# Altium schematic sheet STM32.SchDoc(STM32)
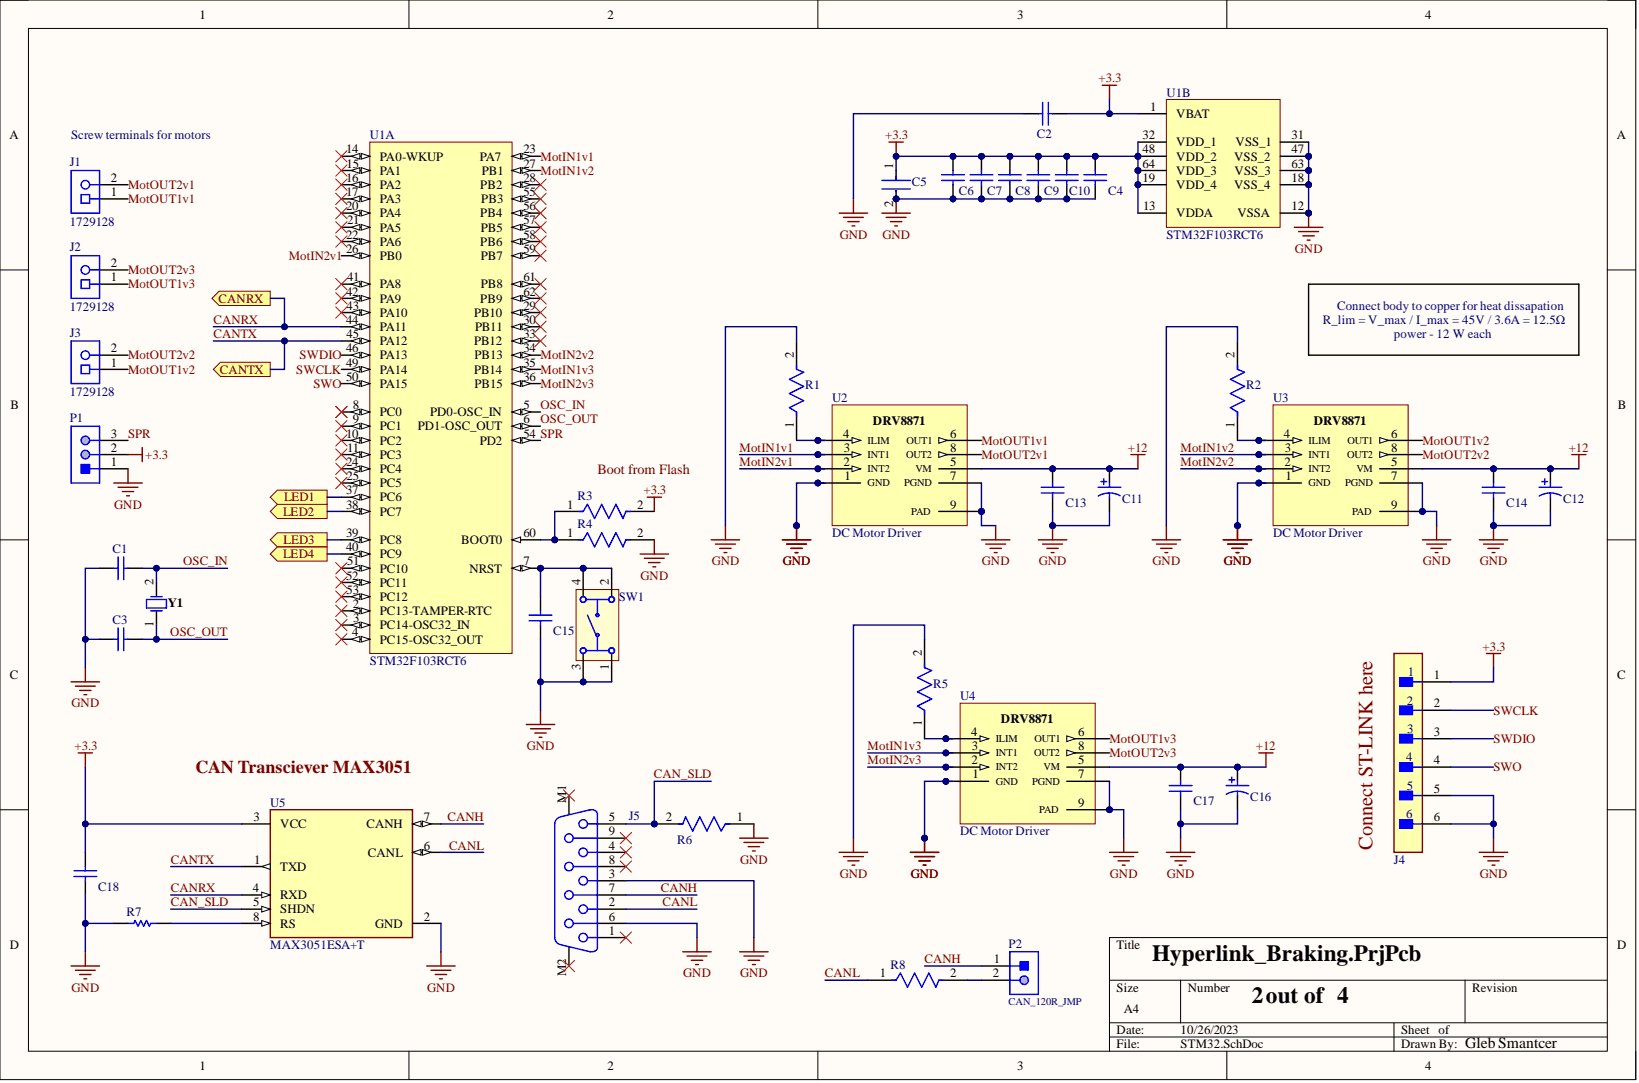
[diagram p0: STM32.SchDoc]

## Parts
C1  GRM1885C2A100JA01D  (value not drawn)
C2  GRM188R71H104KA93D  (value not drawn)
C3  GRM1885C2A100JA01D  (value not drawn)
C4  GRM188R71A105KA61D  (value not drawn)
C5  GRM188R61E475KE11D  (value not drawn)
C6  GRM188R71H104KA93D  (value not drawn)
C7  GRM188R71H104KA93D  (value not drawn)
C8  GRM188R71H104KA93D  (value not drawn)
C9  GRM188R71H104KA93D  (value not drawn)
C10  GRM188R71H104KA93D  (value not drawn)
C11  EEE-HA1H470XP  (value not drawn)
C12  EEE-HA1H470XP  (value not drawn)
C13  GRM188R71H104KA93D  (value not drawn)
C14  GRM188R71H104KA93D  (value not drawn)
C15  GRM188R71H104KA93D  (value not drawn)
C16  EEE-HA1H470XP  (value not drawn)
C17  GRM188R71H104KA93D  (value not drawn)
C18  GRM188R71H104KA93D  (value not drawn)
J1  1729128
J2  1729128
J3  1729128
J4  901210766  (value not drawn)
J5  618009231121  (value not drawn)
P1  S3B-XH-A(LF)(SN)  (value not drawn)
P2  CAN_120R_JMP
R1  CRCW060314R0FKEA  (value not drawn)
R2  CRCW060314R0FKEA  (value not drawn)
R3  0Ω  (value not drawn)  (no BOM)
R4  0Ω  (value not drawn)
R5  CRCW060314R0FKEA  (value not drawn)
R6  CRCW060310K0JNEA  (value not drawn)
R7  CRCW060310K0JNEA  (value not drawn)
R8  CRCW0603120RJNEA  (value not drawn)
SW1  430182070816  (value not drawn)
U1  STM32F103RCT6  (parts A,B)
U2  DC Motor Driver
U3  DC Motor Driver
U4  DC Motor Driver
U5  MAX3051ESA+T
Y1  FOXSDLF/080-20  (value not drawn)

## Ports
CANRX, CANTX, LED1, LED2, LED3, LED4

## Nets
+3.3: C2.2 C4.2 C5.1 C6.2 C7.2 C8.2 C9.2 C10.2 C18.2 J4.1 P1.2 R3.2 U1.1(VBAT) U1.13(VDDA) U1.19(VDD_4) U1.32(VDD_1) U1.48(VDD_2) U1.64(VDD_3) U5.3(VCC)
+12: C11.1 C12.1 C13.2 C14.2 C16.1 C17.2 U2.5(VM) U3.5(VM) U4.5(VM)
GND: C1.1 C2.1 C3.1 C4.1 C5.2 C6.1 C7.1 C8.1 C9.1 C10.1 C11.2 C12.2 C13.1 C14.1 C15.1 C16.2 C17.1 C18.1 J4.5 J4.6 J5.3 J5.6 P1.1 R1.2 R2.2 R4.2 R5.2 R6.1 R7.2 SW1.1 SW1.3 U1.12(VSSA) U1.18(VSS_4) U1.31(VSS_1) U1.47(VSS_2) U1.63(VSS_3) U2.1(GND) U2.7(PGND) U2.9(PAD) U3.1(GND) U3.7(PGND) U3.9(PAD) U4.1(GND) U4.7(PGND) U4.9(PAD) U5.2(GND)
CAN_SLD: J5.5 R6.2 U5.5(SHDN)
CANH: J5.7 P2.1 U5.7(CANH)
CANL: J5.2 R8.1 U5.6(CANL)
CANRX: U1.44(PA11) U5.4(RXD) ; port CANRX
CANTX: U1.45(PA12) U5.1(TXD) ; port CANTX
LED1: U1.37(PC6) ; port LED1
LED2: U1.38(PC7) ; port LED2
LED3: U1.39(PC8) ; port LED3
LED4: U1.40(PC9) ; port LED4
MotIN1v1: U1.23(PA7) U2.3(INT1)
MotIN1v2: U1.27(PB1) U3.3(INT1)
MotIN1v3: U1.35(PB14) U4.3(INT1)
MotIN2v1: U1.26(PB0) U2.2(INT2)
MotIN2v2: U1.34(PB13) U3.2(INT2)
MotIN2v3: U1.36(PB15) U4.2(INT2)
MotOUT1v1: J1.1 U2.6(OUT1)
MotOUT1v2: J3.1 U3.6(OUT1)
MotOUT1v3: J2.1 U4.6(OUT1)
MotOUT2v1: J1.2 U2.8(OUT2)
MotOUT2v2: J3.2 U3.8(OUT2)
MotOUT2v3: J2.2 U4.8(OUT2)
OSC_IN: C1.2 U1.5(PD0-OSC_IN) Y1.2
OSC_OUT: C3.2 U1.6(PD1-OSC_OUT) Y1.1
SPR: P1.3 U1.54(PD2)
SWCLK: J4.2 U1.49(PA14)
SWDIO: J4.3 U1.46(PA13)
SWO: J4.4 U1.50(PA15)
NetC15_2: C15.2 SW1.2 SW1.4 U1.7(NRST)
NetP2_2: P2.2 R8.2
NetR1_1: R1.1 U2.4(ILIM)
NetR2_1: R2.1 U3.4(ILIM)
NetR3_1: R3.1 R4.1 U1.60(BOOT0)
NetR5_1: R5.1 U4.4(ILIM)
NetR7_1: R7.1 U5.8(RS)

Not connected: J5 1 4 8 9 M1 M2; U1 2(PC13-TAMPER-RTC) 3(PC14-OSC32_IN) 4(PC15-OSC32_OUT) 8(PC0) 9(PC1) 10(PC2) 11(PC3) 14(PA0-WKUP) 15(PA1) 16(PA2) 17(PA3) 20(PA4) 21(PA5) 22(PA6) 24(PC4) 25(PC5) 28(PB2) 29(PB10) 30(PB11) 33(PB12) 41(PA8) 42(PA9) 43(PA10) 51(PC10) 52(PC11) 53(PC12) 55(PB3) 56(PB4) 57(PB5) 58(PB6) 59(PB7) 61(PB8) 62(PB9)

## Library data of the parts
C1 C3 = CMP-1035-00565-2: Manufacturer: Murata; Component Kind: Standard; JLC: C1634; Library Name: Altium Content Vault; Part Number: GRM1885C2A100JA01D
C2 C6-C10 C13-C15 C17 C18 = CMP-2100-03643-1: Chip Capacitor, 0.1 uF, +/- 10%, 50 V, -55 to 125 degC, 0603 (1608 Metric), RoHS, Tape and Reel; Manufacturer: Murata; Capacitance: 100nF; Dielectric: X7R; Dielectric Material: Ceramic; Insulation Resistance: 10GR; Voltage: 50V; Case Code (Imperial): 0603; Case Code (Metric): 1608; Case/Package: 0603; Component Kind: Standard; Contact Plating: Tin; Datasheet URL: http://psearch.en.murata.com/capacitor/product/GRM188R71H104KA93%23.pdf; Datasheet Version: 42675.0; Depth: 0.8mm; Height: 0.8mm; JLC: C14663; Lead Free: LeadFree; Length: 1.6mm; Library Name: Altium Content Vault; Manufacturer URL: http://www.murata.com/; Material: Ceramic; Max Operating Temperature: 125degC; Min Operating Temperature: -55degC; Mount: SurfaceMount; Number of Pins: 2; Package Description: 2-Pin Surface Mount Device, Body 1.6 x 0.8 mm; Package Quantity: 1; Package Reference: 0603; Packaging: TapeandReel; Radiation Hardening: No; REACH SVHC: NoSVHC; RoHSCompliant: true; Termination: SMD/SMT; Thickness: 0.035inch; Tolerance: 10%; Voltage Rating: 50V; Voltage Rating (DC): 50V; Width: 0.8mm
C4 = CMP-2006-02831-1: Manufacturer: Murata; Component Kind: Standard; JLC: C15849; Library Name: Altium Content Vault; Part Number: GRM188R71A105KA61D
C5 = CMP-2006-02523-2: Chip Multilayer Ceramic Capacitors for General Purpose, 0603, 4.7uF, X5R, 15%, 10%, 25V; Capacitance: 4.7uF; Case Code (Imperial): 0603; Case Code (Metric): 1608; Case/Package: 0603; Component Kind: Standard; Depth: 0.8mm; Dielectric: X5R; Height: 0.8mm; JLC: C19666; Lead Free: Lead Free; Length: 1.6mm; Library Name: Altium Content Vault; Material: Ceramic; Max Operating Temperature: 85°C; Min Operating Temperature: -55°C; Mount: Surface Mount; Package Quantity: 4000; Packaging: Cut Tape; REACH SVHC: No SVHC; Thickness: 0.031inch; Tolerance: 10%; Voltage: 25V; Voltage Rating: 25V; Voltage Rating (DC): 25V; Width: 0.031inch
C11 C12 C16 = CMP-0736-00001-1: Aluminum Electrolytic Capacitor, 47 uF +/- 20%, 50 V, -40 to 105 degC, 2-Pin 6.6 x 6.6 x 8 mm SMD, RoHS, Tape and Reel, Tape and Reel; Manufacturer: Panasonic; Cap.: 47 uF; Capacitance Tolerance: +/- 20%; Rated Voltage: 50 V; Ripple Current: 63 mA; Component Kind: Standard; ComponentLink1Description: Manufacturer URL; ComponentLink1URL: http://industrial.panasonic.com/; ComponentLink2Description: Datasheet; ComponentLink2URL: http://industrial.panasonic.com/www-data/pdf/ABA0000/ABA0000CE3.pdf; ComponentLink3Description: Package Specification; ComponentLink3URL: http://industrial.panasonic.com/www-data/pdf/ABA0000/ABA0000PE248.pdf; DatasheetVersion: Sep-13; JLC: C249976; Library Name: Altium Content Vault; Mounting Technology: Surface Mount; PackageDescription: 2-Pin SMD, Body 6.6 x 6.6 mm, Height 8 mm; PackageReference: EEE-HA1H470XP-2; PackageVersion: Sep-13; Packing: Tape and Reel; PartNumber: EEE-HA1H470XP; RoHS: TRUE; Temp. Range: -40 to 105 degC
J1-J3 = CMP-2000-05815-1: PCB Terminal Block, 13.5 A, 400 V, 2-Pin THD, RoHS, Bulk; Manufacturer: Phoenix Contact; Current Rating: 13500mA; No. of Positions: 2; No. of Rows: 1; Package Version: 03/2015; Pitch: 5.08mm; Voltage Rating: 400V; Component Kind: Standard; Datasheet URL: https://datasheet.ciiva.com/16046/1729128-16046181.pdf; Datasheet Version: 12/2013; JLC: C669322; Library Name: Altium Content Vault; Manufacturer URL: http://www.phoenixcontact.com/; Mounting Technology: ThroughHole; Orientation: Horizontal; Package Description: 2-Pin Through Hole Device, Body 8.1 x 10.16 mm, Pitch 5.08 mm; Package Reference: 1729128-2; Package URL: https://www.phoenixcontact.com/online/portal/pi/pxc/product_detail_page/!ut/p/b1/3VdJjqNKFDxLH8AFycySyWY2ozFsEPOMMQaDOX1XedGL_1Vdm5YXJleknhSKeBkvI6EA8ncAo3AYoDhBQGco6KN7VURTdemj9us_IEKEZo_YHiPAEWEFmDGOHMORkqKYCORBZxgL7ZoaZYcbFFUZ3fqxSY6GOuxydWUuHqz1ynmMFygu583Bob0Ewr6Sd_l-5m-kAueHiB0jpRwZwe58wwtkpxtMYd_LmGcDRU02dRNEyWt6Y2ti5pSyJ_reIkzIulrqApdnbT11V5jnCKbYNCvhEM1MCpPByat_X379-iThf08CI5GfOPqfBeS3BRoBOdD55AKVv0iLwCMq-2BrzXWSGRH7pUGD9uwXQjiph3xL0DKQwdGa0JImiIOmBNOestqgwTHSSs2oOep-78apyXQBvNi8ZLaFjvjWvcaUEBu0MC6Wbe-d6mB0FAebo2lUWinqYaZs5DAYzV0RP9yitUjnGJ0iI9xhZkT42OCQNUJJhkgWINNQLP2JFP6OpMg3JCVg70jqHT0lvKOnhHf0lPuOnnLf0VPuWx6_dxwUIvwk5WMkT0kLL1mh61l2yZVSzG3pYV_bqo-mj6uMKwKwdnnW8Jh5RTOJzMOA5c-eJDaZQw4mTMPH02PvS_ZAqNVjVTo2WyYLkwH_KU1mmmG5kjRhDqPXLwwVHvVOjCM60-fpXvTDfMGW1PVFVS1r4e4yVDk4ZdNbkUHvqPqQSeian4UD39fNKmachqdJ1NJbyk8rXT9mXTvPk3oE5meulKGgiruPJek-4I9hTT6G8ZLOyRSm2RRVbThERQad5OS28hdt4YW4vG0stgYYzsWsK4_7y6DZMjJSCqri1kyiZR5vD7pwIrNR7QwPHTcLTI5qT7eRlpHrdGiupYlqQDJ7zy_CND8fWWBoLRXxk1RVcXiBQwbTD4Xi7KL-FuO94t9xaqweXzH; Packing: Bulk; PartNumber: 1729128; RoHS: TRUE
J4 = CMP-04776-000096-1: CONN HEADER R/A 6POS 2.54MM; Manufacturer: Molex; Conn Gender: Male; Conn Orientation: Right Angle; Current Rating: 3A; Number Of Contacts: 6; Voltage Rating: 350V; Automotive: No; Category: Conn; Component Kind: Standard; ComponentLink2Description: Datasheet; ComponentLink2URL: https://www.molex.com/webdocs/datasheets/pdf/en-us/0901210766_PCB_HEADERS.pdf; Contact Material: Gold,Nickel; Device Class L1: Connectors; Device Class L2: Headers and Wire Housings; Device Class L3: unset; Digikey Description: CONN HEADER R/A 6POS 2.54MM; Footprint Url: https://www.molex.com/pdm_docs/sd/901210766_sd.pdf; Height: 3.6mm; JLC: C225492; Lead Free: Yes; Library Name: Altium Content Vault; Manufacturer Part Number: 901210766; Number Of Rows: 1; Octopart: https://octopart.com/90121-0766-molex-655456; Package: 0; Pitch: 2.54mm; RoHS: TRUE; Temperature: -55°C to +125°C; Temperature Range High: +125°C; Temperature Range Low: -55°C
J5 = CMP-1502-00200-1: Female PCB Connector with Hex Screw WR-DSUB, 8.08 mm, Angled, 9 pins; Manufacturer: Wurth Elektronik; Contact Resistance: 30mOhm; Pin Number: 9; Rated Current: 3A; Working Voltage: 125VAC; Component Kind: Standard; Datasheet URL: https://katalog.we-online.de/em/datasheet/6180xx231121.pdf; Gender: Female; JLC: C75754; Library Name: Altium Content Vault; Manufacturer URL: http://www.we-online.de; Mounting Technology: THT; Operating Temperature: -40to85degC; Orientation: Angled; Package Reference: 618009231121; Packaging: Tray; Part Number: 618009231121; Publisher: WurthElektronik
P1 = CMP-002-00033-1: Male Header, Pitch 2.5 mm, 1 x 3 Position, Height 6.1 mm, Tail Length 3.4 mm, -25 to 85 degC, RoHS, Bulk; Manufacturer: JST; Case/Package: S3B-XH-A(LF)(SN); Component Kind: Standard; Connector Type: Header; Datasheet URL: http://www.jst-mfg.com/product/pdf/eng/eXH.pdf; Datasheet Version: May-16; Gender: Male; Manufacturer URL: http://www.jst.com/home2.html; Max Operating Temperature: 85°C; Min Operating Temperature: -25°C; Mounting Technology: TH; Package Description: Male Header, Pitch 2.5 mm, 1 x 3 Position, Height 6.1 mm, Tail Length 3.4 mm; Package Reference: S3B-XH-A(LF)(SN); RoHS Compliant: Unknown; Library Name: QMUL Hyperlink
P2 = CMP-1502-01059-1: THT Angled Pin Header WR-PHD, Pitch 2.54 mm, Single Row, 2 pins; Manufacturer: Wurth Elektronik; Part Number: 61300211021; Contact Resistance: 20mOhm; Gender: Male; Pin Number: 2; Pitch: 2.54mm; Rated Current: 3A; Withstanding Voltage: 500VAC; Working Voltage: 250VAC; Component Kind: Standard; Datasheet URL: https://katalog.we-online.de/em/datasheet/6130xx11021.pdf; JLC: C225488; Library Name: Altium Content Vault; Manufacturer URL: http://www.we-online.de; Mounting Technology: THT; Operating Temperature: -40to105degC; Orientation: Angled; Package Reference: 61300211021; Packaging: Bag; Row Number: Single; Publisher: WurthElektronik
R1 R2 R5 = CMP-03211-000738-1: RES SMD 21.5KΩ 0.1% 1/16W 0603; Manufacturer: TE Connectivity; Manufacturer Part Number: CPF0603B21K5E1; Power Rating: 0.063W; Temperature Coefficient: 25ppm/°C; Voltage Rating: 50V; Automotive: No; Category: Res; Component Kind: Standard; ComponentLink2Description: Datasheet; ComponentLink2URL: https://www.te.com/commerce/DocumentDelivery/DDEController?Action=showdoc&DocId=Data+Sheet%7F1773200%7FM%7Fpdf%7FEnglish%7FENG_DS_1773200_M.pdf%7F3-1676481-2; Composition: Thin Film; Device Class L1: Passive Components; Device Class L2: Resistors; Device Class L3: Chip SMD Resistors; Height: 0.55mm; Ipc Land Pattern Name: RESC15580X45; JLC: C22911; Lead Free: Yes; Library Name: Altium Content Vault; Octopart: https://octopart.com/cpf0603b21k5e1-te+connectivity-22739640; octopart_url: https://octopart.com/cpf0603b21k5e1-te+connectivity-22739640; Package: CPF0603; packaging: 1k Reel; Resistance: 21.5KΩ; RoHS: TRUE; Series: CPF; Temperature: -55°C to +155°C; Temperature Range High: +155°C; Temperature Range Low: -55°C; Tolerance: 0.1%; Value: 21.5KΩ
R3 R4 = CMP-2000-03817-2: RES Thick Film, 0Ω, , 0.33W, , 0603; Max Power Dissipation: 250mW; Resistance: 0mR; Temperature Coefficient: 0ppm/°C; Voltage Rating: 75V; Case Code (Imperial): 0603; Case Code (Metric): 1608; Case/Package: 0603; Composition: Thick Film; Contact Plating: Tin; Depth: 0.85mm; Features: Automotive AEC-Q200; Height: 0.45mm; JLC: C21189; Lead Free: Lead Free; Length: 1.6mm; Library Name: Altium Content Vault; Max Operating Temperature: 155°C; Military Standard: Not; Min Operating Temperature: -55°C; Mount: Surface Mount; Number of Pins: 2; Number of Terminations: 2; Package Quantity: 5000; Packaging: Tape and Reel; Power Rating: 250mW; Radiation Hardening: No; Termination: SMD/SMT; Voltage Rating (DC): 75V; Weight: 7.1E-05oz; Width: 0.033inch
  R4: Component Kind: Standard
R6 = CMP-2000-03838-2: RES Thick Film, 10kΩ, 5%, 0.1W, 200ppm/°C, 0603; Max Power Dissipation: 100mW; Resistance: 10kR; Voltage Rating: 75V; Case Code (Imperial): 0603; Case Code (Metric): 1608; Case/Package: 0603; Component Kind: Standard; Composition: Thick Film; Contact Plating: Tin; Depth: 0.85mm; Features: Automotive AEC-Q200; Height: 0.02inch; JLC: C25804; Lead Free: Lead Free; Length: 1.55mm; Library Name: Altium Content Vault; Max Operating Temperature: 155°C; Military Standard: Not; Min Operating Temperature: -55°C; Mount: Surface Mount; Number of Pins: 2; Number of Terminations: 2; Package Quantity: 1; Packaging: Tape and Reel; Power Rating: 100mW; Radiation Hardening: No; Temperature Coefficient: 200ppm/°C; Termination: SMD/SMT; Tolerance: 5%; Voltage Rating (DC): 75V; Weight: 7.1E-05oz; Width: 0.033inch
R7 = CMP-1012-00667-2: Manufacturer: Panasonic; Part Number: ERJ-3EKF2552V; Component Kind: Standard; JLC: C23352; Library Name: Altium Content Vault
R8 = CMP-1012-00052-3: RES Thick Film, 120Ω, 5%, 0.1W, 200ppm/°C, 0603; Max Power Dissipation: 100mW; Resistance: 120R; Voltage Rating: 75V; Case Code (Imperial): 0603; Case Code (Metric): 1608; Case/Package: 0603; Component Kind: Standard; Composition: Thick Film; Contact Plating: Tin; Depth: 0.85mm; Features: Automotive AEC-Q200; Height: 0.02inch; JLC: C22787; Lead Free: Lead Free; Length: 1.55mm; Library Name: Altium Content Vault; Max Operating Temperature: 155°C; Military Standard: Not; Min Operating Temperature: -55°C; Number of Pins: 2; Number of Terminations: 2; Package Quantity: 1; Packaging: Tape and Reel; Power Rating: 100mW; Radiation Hardening: No; Temperature Coefficient: 200ppm/°C; Termination: SMD/SMT; Tolerance: 5%; Voltage Rating (DC): 75V; Weight: 7.1E-05oz; Width: 0.85mm
SW1 = CMP-1452-00015-1: WS-TASV SMD Tact Switch 6X6 mm; Manufacturer: Wuerth Elektronik; NoPins: 04; Component Kind: Standard; ComponentLink1Description: Manufacturer URL; ComponentLink1URL: http://www.we-online.com; ComponentLink2Description: Datasheet; ComponentLink2URL: http://katalog.we-online.de/em/datasheet/4301x2.pdf; JLC: C620316; Library Name: Altium Content Vault; PackageReference: 430182070816; PartNumber: 430182070816
U1 = CMP-0237-00009-4: ARM Cortex-M3 32-bit MCU, 256 KB Flash, 48 KB Internal RAM, 51 I/Os, 64-pin LQFP, -40 to 85 degC, Tray; A/D Converter: 3x12-bit (16 Channel); D/A Converter: 2x12-bit (2 Channel); FLASH Size (Prog) (kB): 256; I/Os (High Current): 51; Internal RAM Size (kB): 48; Operating Frequency(F) (Processor speed) (MHz): 72; Supply Voltage(Vcc) min (V): 2; Component Kind: Standard; ComponentLink1Description: Manufacturer URL; ComponentLink1URL: http://www.st.com/web/en/home.html; ComponentLink2Description: Datasheet; ComponentLink2URL: http://www.st.com/st-web-ui/static/active/en/resource/technical/document/datasheet/CD00191185.pdf; ComponentLink3Description: Package Specification; ComponentLink3URL: http://www.st.com/st-web-ui/static/active/en/resource/technical/document/datasheet/DM00037051.pdf; Core: ARM Cortex-M3; DatasheetVersion: Rev. 8; JLC: C8322; Library Name: Altium Content Vault; Mounting Technology: Surface Mount; PackageDescription: 64-Pin Low Profile Quad Flat Package, 10 x 10 mm Body, 0.5 mm Pitch; PackageReference: LQFP64; PackageVersion: Rev. 4, 06/2013; Packing: Tray; PartNumber: STM32F103RCT6
U2-U4 = DRV8871: 3.6-A Brushed DC Motor Driver With Internal Current Sense (PWM Control).; Component Kind: Standard; JLC: C75864; Library Name: Hyperlink_Int_Library.IntLib
U5 = CMP-05676-000007-1: IC TRANSCEIVER HALF 1/1 8SO; Data Rate: 1Mbps; Interface: CAN; Max Supply Voltage: 3.465V; Min Supply Voltage: 3.135V; Number of Receive Buffers: 1; Operating Supply Current: 35mA; Operating Supply Voltage: 3.3V; Receiver Hysteresis: 20mV; Simplex/Duplex: Full Duplex; Case/Package: SO; Component Kind: Standard; JLC: C15648; Lead Free: Lead Free; Library Name: Altium Content Vault; Max Operating Temperature: 85°C; Min Operating Temperature: -40°C; Mount: Surface Mount; Number of Pins: 8; Number of Transceivers: 1; Number of Transmit Buffers: 1; Package Quantity: 2500; Packaging: Tape and Reel; Radiation Hardening: No; Weight: 0.01787oz
Y1 = CMP-2100-03639-1: Resistance Weld Crystal, 8 MHz, +/- 30 ppm, -20 to 70 degC, 2-Pin SMD, RoHS, Tape and Reel; Manufacturer: FOX Electronics; ESR (Equivalent Series Resistance): 80Ohm; Frequency: 8MHz; Frequency Stability: 50ppm; Frequency Tolerance: 30ppm; Load Capacitance: 20pF; Case/Package: SMD/SMT; Component Kind: Standard; Datasheet URL: http://www.foxonline.com/pdfs/hc49sdlf.pdf; Datasheet Version: 42064.0; Depth: 5mm; Height: 4.5mm; JLC: C12674; Lead Free: LeadFree; Length: 11.7mm; Library Name: Altium Content Vault; Manufacturer URL: http://www.foxonline.com/; Max Operating Temperature: 70degC; Min Operating Temperature: -20degC; Mount: SurfaceMount; Number of Pins: 2; Package Description: 2-Pin Surface Mount Device, Body 5 x 11.7 mm; Package Quantity: 1000; Package Reference: HC49SDLF; Packaging: TapeandReel; Radiation Hardening: No; REACH SVHC: NoSVHC; RoHSCompliant: True; Series Resistance: 80Ohm; Termination: SMD/SMT; Tolerance: 50ppm; Width: 5mm
